# Altium schematic sheet ReboostV2_MC.SchDoc
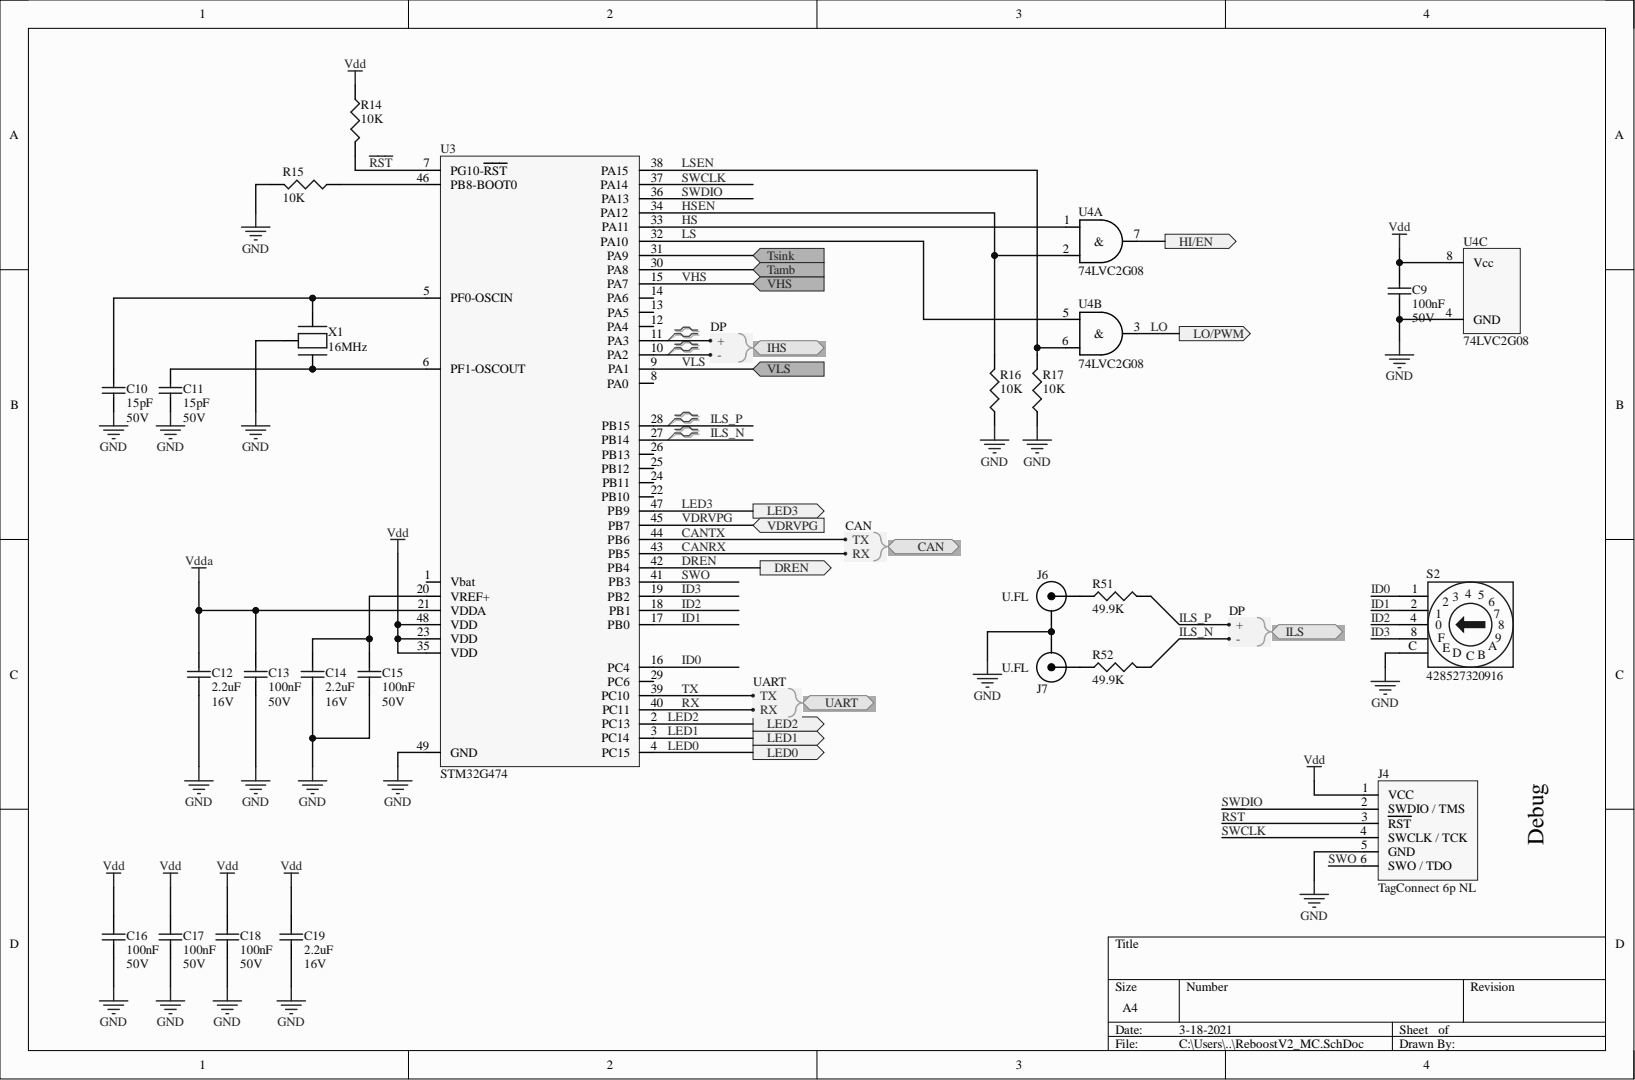
[diagram p0: ReboostV2_MC.SchDoc]

## Parts
C9  100nF  Voltage Rating: 50V  [C0603]
C10  15pF  Voltage Rating: 50V  [C0603]
C11  15pF  Voltage Rating: 50V  [C0603]
C12  2.2uF  Voltage Rating: 16V  [C0603]
C13  100nF  Voltage Rating: 50V  [C0603]
C14  2.2uF  Voltage Rating: 16V  [C0603]
C15  100nF  Voltage Rating: 50V  [C0603]
C16  100nF  Voltage Rating: 50V  [C0603]
C17  100nF  Voltage Rating: 50V  [C0603]
C18  100nF  Voltage Rating: 50V  [C0603]
C19  2.2uF  Voltage Rating: 16V  [C0603]
J4  TagConnect 6p NL  [TC2030-IDC-NL]  (no BOM)
J6  U.FL  [U_FL]
J7  U.FL  [U_FL]
R14  10K  [0603]
R15  10K  [0603]
R16  10K  [0603]
R17  10K  [0603]
R51  49.9K  [0603]
R52  49.9K  [0603]
S2  428527320916  [42852732091x_16p]
U3  STM32G474  [QFN48]
U4  74LVC2G08  [TSSOP8]  (parts A,B,C)
X1  16MHz  [7M]

## Ports
CAN, CAN.RX, CAN.TX, DREN, HI/EN, IHS, IHS.+, IHS.-, ILS, ILS.+, ILS.-, LED0, LED1, LED2, LED3, LO/PWM, Tamb, Tsink, UART, UART.RX, UART.TX, VDRVPG, VHS, VLS

## Nets
GND: C9.1 C10.1 C11.1 C12.1 C13.1 C14.1 C15.1 C16.1 C17.1 C18.1 C19.1 J4.5(GND) J6.0 J7.0 R15.2 R16.1 R17.1 S2.C(E_D_C_B) U3.49(GND) U4.4(GND) X1.0
Vdd: C9.2 C16.2 C17.2 C18.2 C19.2 J4.1(VCC) R14.1 U3.23(VDD) U3.35(VDD) U3.48(VDD) U4.8(Vcc)
Vdda: C12.2 C13.2 U3.21(VDDA)
CANRX: U3.43(PB5) ; port CAN
CANTX: U3.44(PB6) ; port CAN
DREN: U3.42(PB4) ; port DREN
HI/EN: U4.7(&) ; port HI/EN
HS: U3.33(PA11) U4.1
HSEN: R16.2 U3.34(PA12) U4.2
ID0: S2.1 U3.16(PC4)
ID1: S2.2 U3.17(PB0)
ID2: S2.4 U3.18(PB1)
ID3: S2.8(F) U3.19(PB2)
ILS_N: R52.2 U3.27(PB14) ; port ILS
ILS_P: R51.2 U3.28(PB15) ; port ILS
LED0: U3.4(PC15) ; port LED0
LED1: U3.3(PC14) ; port LED1
LED2: U3.2(PC13) ; port LED2
LED3: U3.47(PB9) ; port LED3
LO: U4.3(&) ; port LO/PWM
LS: U3.32(PA10) U4.5
LSEN: R17.2 U3.38(PA15) U4.6
~{RST}: J4.3(RST) R14.2 U3.7(PG10-RST)
RX: U3.40(PC11) ; port UART
SWCLK: J4.4(SWCLK_/_TCK) U3.37(PA14)
SWDIO: J4.2(SWDIO_/_TMS) U3.36(PA13)
SWO: J4.6(SWO_/_TDO) U3.41(PB3)
Tamb: U3.30(PA8) ; port Tamb
Tsink: U3.31(PA9) ; port Tsink
TX: U3.39(PC10) ; port UART
VDRVPG: U3.45(PB7) ; port VDRVPG
VHS: U3.15(PA7) ; port VHS
VLS: U3.9(PA1) ; port VLS
NetC10_2: C10.2 U3.5(PF0-OSCIN) X1.1
NetC11_2: C11.2 U3.6(PF1-OSCOUT) X1.2
NetC14_2: C14.2 C15.2 U3.20(VREF+)
NetJ6_1: J6.1 R51.1
NetJ7_1: J7.1 R52.1
NetR15_1: R15.1 U3.46(PB8-BOOT0)
NetU3_1: U3.1(Vbat)
NetU3_8: U3.8(PA0)
NetU3_10: U3.10(PA2) ; port IHS
NetU3_11: U3.11(PA3) ; port IHS
NetU3_12: U3.12(PA4)
NetU3_13: U3.13(PA5)
NetU3_14: U3.14(PA6)
NetU3_22: U3.22(PB10)
NetU3_24: U3.24(PB11)
NetU3_25: U3.25(PB12)
NetU3_26: U3.26(PB13)
NetU3_29: U3.29(PC6)

## Library data of the parts
C9 C13 C15-C18 = 100nF/0603/CER/50V: Capacitor, 100nF, 50V, X7R; Brand: MULTICOMP; Brand Number: MCCA000255; Capacitance Tolerance: ± 10%; Capacitor Case Style: 0603; Capacitance: 100nF; Component Kind: Standard; Dielectric Characteristic: X7R; Library Name: AR_Capacitors_Ceramic.IntLib; Order Code Supplier: 1759122RL; Price: 0,008; Supplier: Farnell; Temperature Range: -55°C to +125°C
C10 C11 = 15pF/0603/CER/50V: Capacitor, 15pF, 50V, C0G / NP0; Brand: MULTICOMP; Brand Number: MCCA000194; Capacitance Tolerance: ± 5%; Capacitor Case Style: 0603; Capacitance: 15pF; Component Kind: Standard; Dielectric Characteristic: C0G / NP0; Library Name: AR_Capacitors_Ceramic.IntLib; Order Code Supplier: 1759055; Price: 0,009; Supplier: Farnell; Temperature Range: -55°C to +125°C
C12 C14 C19 = 2.2uF/0603/CER/16V: Capacitor, 2.2uF, 16V, X5R; Brand: MURATA; Brand Number: GRM188R61C225KE15D; Capacitance Tolerance: +/- 10%; Capacitor Case Style: 0603; Capacitance: 2.2uF; Component Kind: Standard; Dielectric Characteristic: X5R; Library Name: AR_Capacitors_Ceramic.IntLib; Order Code Supplier: 1735526; Price: 0,077; Supplier: Farnell; Temperature Range: -55°C to 85°C
J4 = TC2030-IDC: “No Legs” 6-pin Plug-of-Nails™ Cable fitted with a 6-pin 0.1″ pitch ribbon connector.; Library Name: AR_Connectors.IntLib
J6 J7 = U.FL-R-SMT: Connector RF Coax; Brand: Hiroze; Brand Number: U.FL-R-SMT(01); Case Style: U_FL; Component Kind: Standard; Library Name: AR_Connectors.IntLib; Order Code Supplier: 3908021; Price: 1,53; Supplier: Farnell
R14-R17 = 10K/0603/0063W/50V: Resistor, 10K, 1%, 0.063W, 50V, 100PPM, 0603; Brand: VISHAY DRALORIC; Brand Number: CRCW060310K0FKEA; Case Style: 0603; Component Kind: Standard; Library Name: AR_Resistors_SMD.IntLib; Order Code Supplier: 2122493; Power Rating: 0.063W; Price: 0,038; Resistance: 10K; Supplier: Farnell; Temperature Coefficient: ± 100PPM; Temperature Range: -55°C to +125°C; Voltage Rating: 50V
R51 R52 = 49.9K/0603/0063W/50V: Resistor, 49.9K, 1%, 0.063W, 50V, 100PPM, 0603; Brand: VISHAY DRALORIC; Brand Number: CRCW060349K9FKEA; Case Style: 0603; Component Kind: Standard; Library Name: AR_Resistors_SMD.IntLib; Order Code Supplier: 1652882; Power Rating: 0.063W; Price: 0,015; Resistance: 49.9K; Supplier: Farnell; Temperature Coefficient: ± 100PPM; Temperature Range: -55°C to +125°C; Voltage Rating: 50V
S2 = 428527320916: WS-ROTV THT Rotary Switch with Arrow Type Actuator, 10x10mm, 16 positions; Cover Material: PA66; Position Number: 16; Rated Current: 150mA; Rated Voltage: 24VDC; Washable: Yes; Actuator Type: Arrow Type; Component Kind: Standard; ComponentLink1Description: Manufacturer; ComponentLink1URL: http://www.we-online.de; ComponentLink2Description: Datasheet; ComponentLink2URL: https://katalog.we-online.com/em/datasheet/428527320916.pdf; Library Name: Altium_WS-ROTV (rev19a).IntLib; Mounting Technology: THT; Operating Temperature: -40 to +85degC; Packaging: Tube; Part Number: 428527320916; Published Date: September 2019; Size: 10x10mm; Terminal Plating: Gold; Publisher: Wurth Elektronik
U3 = STM32G474CEU6: ARM MCU, STM32 Family STM32G4 Series Microcontrollers, ARM Cortex-M4F, 32bit, 170 MHz, 512 KB; Component Kind: Standard; Library Name: AR_Microcontrollers.IntLib; Supplier 1: Digi-Key; Supplier 2: Farnell; Supplier Part Number 1: 497-STM32G474CEU6-ND; Supplier Part Number 2: 3132392
U4 = 74LVC2G08: Dual 2-input AND gate; Component Kind: Standard; Library Name: AR_ICs.IntLib; Order Code Supplier: 771-74LVC2G08DP-G; Supplier: Mouser
X1 = 7M-16.000MAAJ-T: CRYSTAL, 16MHz,18PF CL, 3.2X2.5MM SMT; Brand: TXC; Brand Number: 7M-16.000MAAJ-T; Component Kind: Standard; Library Name: AR_Crystals.IntLib; Order Code Supplier: 1842060; Price: 1,45; Supplier: Farnell
